# Altium schematic sheet AD Sensor Module.SchDoc("AD Sensor Module")
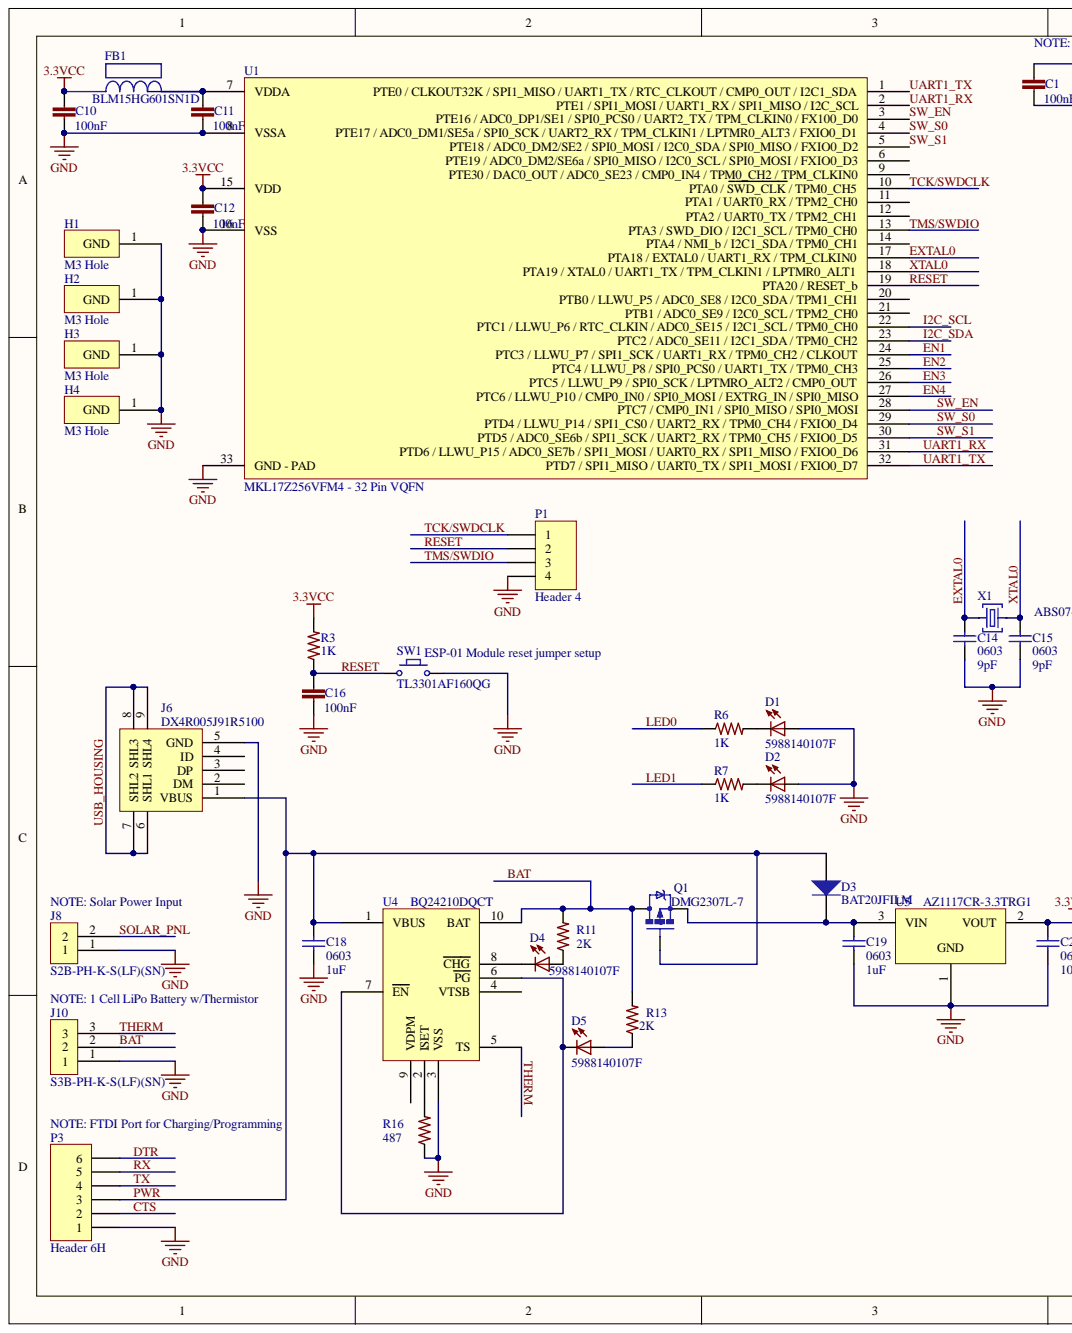
[diagram p0: AD Sensor Module.SchDoc, left]
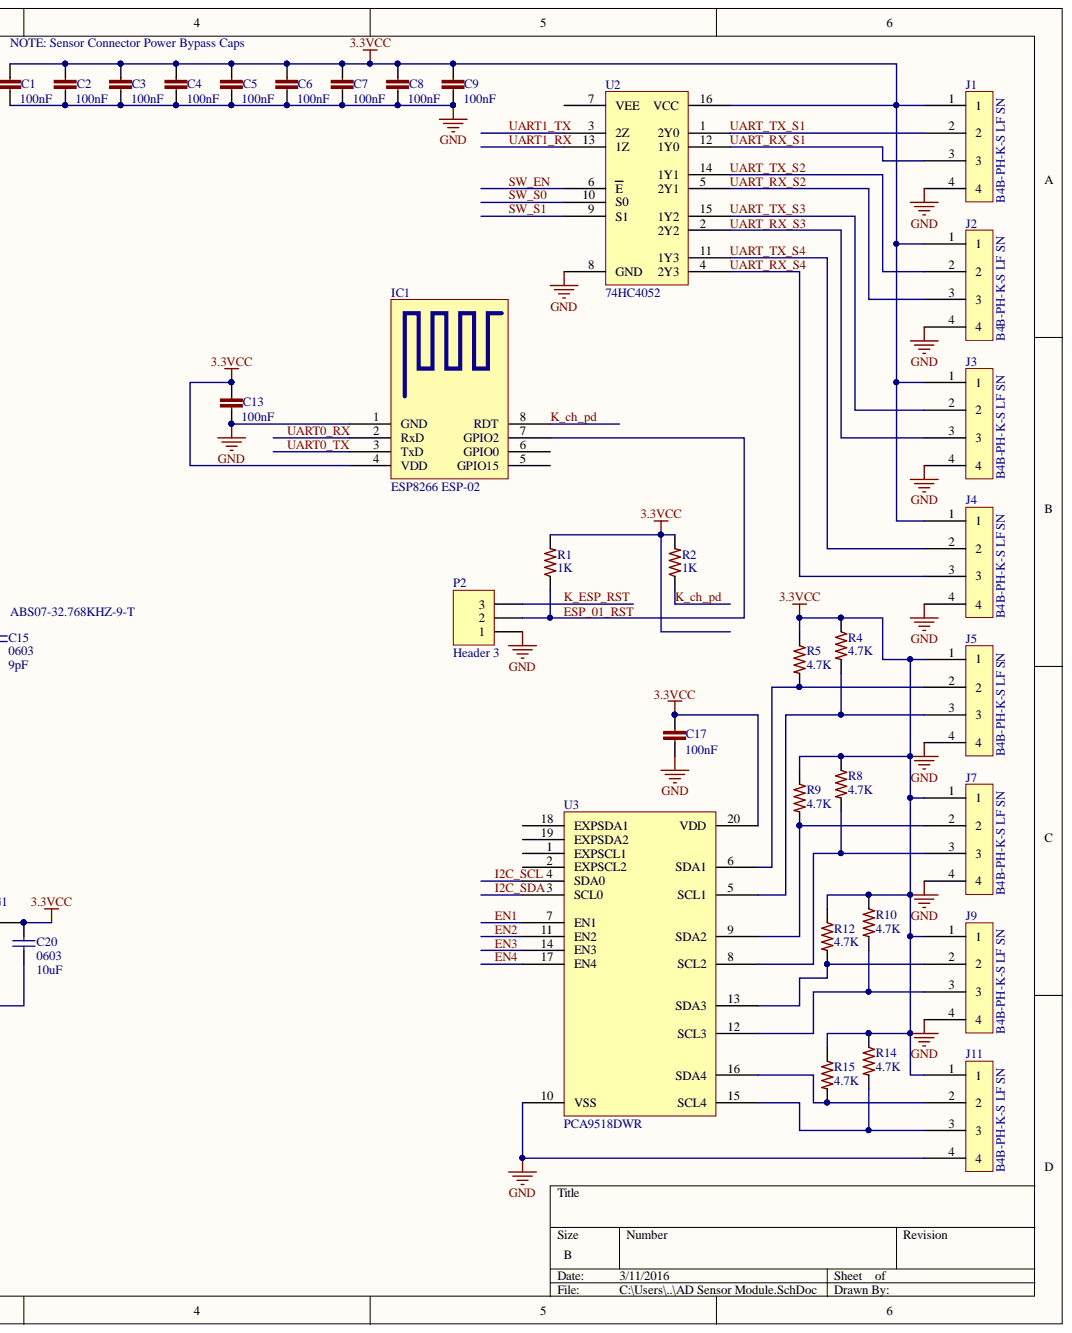
[diagram p1: AD Sensor Module.SchDoc, right]

## Parts
C1  100nF  [C0402]
C2  100nF  [C0402]
C3  100nF  [C0402]
C4  100nF  [C0402]
C5  100nF  [C0402]
C6  100nF  [C0402]
C7  100nF  [C0402]
C8  100nF  [C0402]
C9  100nF  [C0402]
C10  100nF  [C0402]
C11  100nF  [C0402]
C12  100nF  [C0402]
C13  100nF  [C0402]
C14  0603  value 9pF  value 9pF  [C0603]
C15  0603  value 9pF  value 9pF  [C0603]
C16  100nF  [C0402]
C17  100nF  [C0402]
C18  0603  value 1uF  [C0603]
C19  0603  value 1uF  [C0603]
C20  0603  value 10uF  value 10uF  [C0603]
D1  5988140107F  [LED2012120]
D2  5988140107F  [LED2012120]
D3  BAT20JFILM  [BAT20JFILM - DIOM1712X11N]
D4  5988140107F  [LED2012120]
D5  5988140107F  [LED2012120]
FB1  BLM15HG601SN1D  [BLM18PG121SN1D]
H1  M3 Hole  [Hole-3mm]
H2  M3 Hole  [Hole-3mm]
H3  M3 Hole  [Hole-3mm]
H4  M3 Hole  [Hole-3mm]
IC1  ESP8266 ESP-02  [ESP8266 ESP-02]
J1  B4B-PH-K-S LF SN  [B4B-PH-K-S(LF)(SN)]
J2  B4B-PH-K-S LF SN  [B4B-PH-K-S(LF)(SN)]
J3  B4B-PH-K-S LF SN  [B4B-PH-K-S(LF)(SN)]
J4  B4B-PH-K-S LF SN  [B4B-PH-K-S(LF)(SN)]
J5  B4B-PH-K-S LF SN  [B4B-PH-K-S(LF)(SN)]
J6  DX4R005J91R5100  [Micro USB Circular Holes Only]
J7  B4B-PH-K-S LF SN  [B4B-PH-K-S(LF)(SN)]
J8  S2B-PH-K-S(LF)(SN)  [S2B-PH-K-S(LF)(SN)]
J9  B4B-PH-K-S LF SN  [B4B-PH-K-S(LF)(SN)]
J10  S3B-PH-K-S(LF)(SN)  [S3B-PH-K-S(LF)(SN)]
J11  B4B-PH-K-S LF SN  [B4B-PH-K-S(LF)(SN)]
P1  Header 4  [HDR1X4]
P2  Header 3  [HDR1X3]
P3  Header 6H  [HDR1X6H]
Q1  DMG2307L-7  [DMG2307L-7 - SOT92P240X102-3N]
R1  1K  [R0603]
R2  1K  [R0603]
R3  1K  [R0603]
R4  4.7K  [R0603]
R5  4.7K  [R0603]
R6  1K  [R0603]
R7  1K  [R0603]
R8  4.7K  [R0603]
R9  4.7K  [R0603]
R10  4.7K  [R0603]
R11  value 2K  [R0805]  (hidden comment: ERJ-6ENF2001V)
R12  4.7K  [R0603]
R13  value 2K  [R0805]  (hidden comment: ERJ-6ENF2001V)
R14  4.7K  [R0603]
R15  4.7K  [R0603]
R16  value 487  [R0402]  (hidden comment: RC1005F4870CS)
SW1  TL3301AF160QG  [TL3301AF160QG]
U1  MKL17Z256VFM4 - 32 Pin VQFN  MFR #: MKL17Z256VFM4  [MKL17Z256VFM4 - QFN50P500X500X65_HS-33N]
U2  74HC4052  [74HC4052]
U3  PCA9518DWR  [PCA9518DWR]
U4  BQ24210DQCT  [bq24210 - QFN50P300X200X80-10N]
U5  AZ1117CR-3.3TRG1  [AZ1117CR-3.3TRG1 - SOT89-150P410X160-3N]
X1  ABS07-32.768KHZ-9-T  [ABS07-32.768KHZ-9-T]

## Nets
3.3VCC: C1.1 C2.1 C3.1 C4.1 C5.1 C6.1 C7.1 C8.1 C9.1 C10.1 C12.1 C13.1 C17.1 C20.2 FB1.1 IC1.4(VDD) J1.1 J2.1 J3.1 J4.1 J5.1 J7.1 J9.1 J11.1 R1.2 R2.2 R3.2 R4.1 R5.1 R8.1 R9.1 R10.1 R12.1 R14.1 R15.1 U1.15(VDD) U2.16(VCC) U3.20(VDD) U5.2
GND: C1.2 C2.2 C3.2 C4.2 C5.2 C6.2 C7.2 C8.2 C9.2 C10.2 C11.2 C12.2 C13.2 C14.1 C15.1 C16.2 C17.2 C18.1 C19.1 C20.1 D1.A D2.A H1.1 H2.1 H3.1 H4.1 IC1.1(GND) J1.4 J2.4 J3.4 J4.4 J5.4 J6.5(GND) J7.4 J8.1 J9.4 J10.1 J11.4 P1.4 P2.1 P3.1 R16.1 SW1.2 U1.8(VSSA) U1.16(VSS) U1.33(GND) U2.8(GND) U3.10(VSS) U4.3(VSS) U5.1
BAT: J10.2 Q1.3 R11.2 R13.2 U4.10(BAT)
CTS: P3.2
DTR: P3.6
EN1: U1.24(PTC3_/_LLWU_P7_/_SPI1_SCK_/_UART1_RX_/_TPM0_CH2_/_CLKOUT) U3.7(EN1)
EN2: U1.25(PTC4_/_LLWU_P8_/_SPI0_PCS0_/_UART1_TX_/_TPM0_CH3) U3.11(EN2)
EN3: U1.26(PTC5_/_LLWU_P9_/_SPI0_SCK_/_LPTMRO_ALT2_/_CMP0_OUT) U3.14(EN3)
EN4: U1.27(PTC6_/_LLWU_P10_/_CMP0_IN0_/_SPI0_MOSI_/_EXTRG_IN_/_SPI0_MISO) U3.17(EN4)
ESP_01_RST: IC1.7(GPIO2) P2.2 R1.1
EXTAL0: C14.2 U1.17(PTA18_/_EXTAL0_/_UART1_RX_/_TPM_CLKIN0) X1.1
I2C_SCL: U1.22(PTC1_/_LLWU_P6_/_RTC_CLKIN_/_ADC0_SE15_/_I2C1_SCL_/_TPM0_CH0) U3.4(SDA0)
I2C_SDA: U1.23(PTC2_/_ADC0_SE11_/_I2C1_SDA_/_TPM0_CH2) U3.3(SCL0)
K_CH_PD: IC1.8(RDT) R2.1
K_ESP_RST: P2.3
LED0: R6.1
LED1: R7.1
PWR: C18.2 D3.2 J6.1(VBUS) P3.3 Q1.1 U4.1(VBUS)
RESET: C16.1 P1.2 R3.1 SW1.1 U1.19(PTA20_/_RESET_b)
RX: P3.5
SOLAR_PNL: J8.2
SW_EN: U1.3(PTE16_/_ADC0_DP1/SE1_/_SPI0_PCS0_/_UART2_TX_/_TPM_CLKIN0_/_FX100_D0) U1.28(PTC7_/_CMP0_IN1_/_SPI0_MISO_/_SPI0_MOSI) U2.6(E)
SW_S0: U1.4 U1.29(PTD4_/_LLWU_P14_/_SPI1_CS0_/_UART2_RX_/_TPM0_CH4_/_FXIO0_D4) U2.10(S0)
SW_S1: U1.5(PTE18_/_ADC0_DM2/SE2_/_SPI0_MOSI_/_I2C0_SDA_/_SPI0_MISO_/_FXIO0_D2) U1.30(PTD5_/_ADC0_SE6b_/_SPI1_SCK_/_UART2_RX_/_TPM0_CH5_/_FXIO0_D5) U2.9(S1)
TCK/SWDCLK: P1.1 U1.10(PTA0_/_SWD_CLK_/_TPM0_CH5)
THERM: J10.3 U4.5(TS)
TMS/SWDIO: P1.3 U1.13(PTA3_/_SWD_DIO_/_I2C1_SCL_/_TPM0_CH0)
TX: P3.4
UART0_RX: IC1.2(RxD)
UART0_TX: IC1.3(TxD)
UART1_RX: U1.2(PTE1_/_SPI1_MOSI_/_UART1_RX_/_SPI1_MISO_/_I2C_SCL) U1.31(PTD6_/_LLWU_P15_/_ADC0_SE7b_/_SPI1_MOSI_/_UART0_RX_/_SPI1_MISO_/_FXIO0_D6) U2.13(1Z)
UART1_TX: U1.1 U1.32(PTD7_/_SPI1_MISO_/_UART0_TX_/_SPI1_MOSI_/_FXIO0_D7) U2.3(2Z)
UART_RX_S1: J1.3 U2.12(1Y0)
UART_RX_S2: J2.3 U2.5(2Y1)
UART_RX_S3: J3.3 U2.2(2Y2)
UART_RX_S4: J4.3 U2.4(2Y3)
UART_TX_S1: J1.2 U2.1(2Y0)
UART_TX_S2: J2.2 U2.14(1Y1)
UART_TX_S3: J3.2 U2.15(1Y2)
UART_TX_S4: J4.2 U2.11(1Y3)
USB_HOUSING: J6.6(SHL1) J6.7(SHL2) J6.8(SHL3) J6.9(SHL4)
XTAL0: C15.2 U1.18(PTA19_/_XTAL0_/_UART1_TX_/_TPM_CLKIN1_/_LPTMR0_ALT1) X1.2
NetC11_1: C11.1 FB1.2 U1.7(VDDA)
NetC19_2: C19.2 D3.1 Q1.2 U5.3
NetD1_C: D1.C R6.2
NetD2_C: D2.C R7.2
NetD4_A: D4.A R11.1
NetD4_C: D4.C U4.8(CHG)
NetD5_A: D5.A R13.1
NetD5_C: D5.C U4.6(PG) U4.7(EN)
NetJ5_2: J5.2 R5.2 U3.6(SDA1)
NetJ5_3: J5.3 R4.2 U3.5(SCL1)
NetJ7_2: J7.2 R9.2 U3.9(SDA2)
NetJ7_3: J7.3 R8.2 U3.8(SCL2)
NetJ9_2: J9.2 R12.2 U3.13(SDA3)
NetJ9_3: J9.3 R10.2 U3.12(SCL3)
NetJ11_2: J11.2 R15.2 U3.16(SDA4)
NetJ11_3: J11.3 R14.2 U3.15(SCL4)
NetR16_2: R16.2 U4.2(ISET)

Not connected: IC1 5(GPIO15) 6(GPIO0); J6 2(DM) 3(DP) 4(ID); U1 6(PTE19_/_ADC0_DM2/SE6a_/_SPI0_MISO_/_I2C0_SCL_/_SPI0_MOSI_/_FXIO0_D3) 9(PTE30_/_DAC0_OUT_/_ADC0_SE23_/_CMP0_IN4_/_TPM0_CH2_/_TPM_CLKIN0) 11(PTA1_/_UART0_RX_/_TPM2_CH0) 12(PTA2_/_UART0_TX_/_TPM2_CH1) 14(PTA4_/_NMI_b_/_I2C1_SDA_/_TPM0_CH1) 20(PTB0_/_LLWU_P5_/_ADC0_SE8_/_I2C0_SDA_/_TPM1_CH1) 21(PTB1_/_ADC0_SE9_/_I2C0_SCL_/_TPM2_CH0); U2 7(VEE); U3 1(EXPSCL1) 2(EXPSCL2) 18(EXPSDA1) 19(EXPSDA2); U4 4(VTSB) 9(VDPM)

## Library data of the parts
C1-C13 C16 C17 = GRM155R71C104KA88D: CAP CER 0402 100nF 16V 10% X7R; LastRevisionNote: ImportComponents; Component Kind: Standard; LastRevisionDate: 23-Apr-2014; Library Name: 3Delight.SCHLIB; ManufacturerPartNumber: GRM155R71C104KA88D; Published: 23-Apr-2014; Signal Integrity: Model Name; Simulation: Model Name; VALUE: 100nF; Publisher: UltiMachine
C14 C15 = CL10C090CB8NNNC: CAP CER 9PF 50V NP0 0603; MFR: Samsung Electro-Mechanics America, Inc.; Designer: Adam Vadala-Roth; Lead-Free ?: Yes; Pin Count #: 2; Tolerance: 10%; Type: Capacitor / Passive; Voltage: 6.3V; Component Kind: Standard; Cost: $0.00805 @ 4000; Datasheet: http://www.samsungsem.com/kr/support/product-search/mlcc/__icsFiles/afieldfile/2015/06/03/CL10C090CB8NNNC.pdf; Date Created: 11 Mar 2016; Height: 0.9mm; Last Modified: 11 Mar 2016; Library Name: Sensor Package.SchLib; MFR #: CL10C090CB8NNNC; Mounting: SMT; Status: Available
C18 C19 = CL05A105KA5NQNC: CAP CER 1UF 25V X5R 0402; MFR: Samsung; Tolerance: 1%; Voltage: 50VDC; Component Kind: Standard; Component Type: Chip Capacitor; Datasheet: http://www.samsungsem.com/kr/front/downloadcms.do?path=/kr/support/product-search/mlcc/__icsFiles/afieldfile/2014/11/05&fileName=S_CL10B223JB8NNNC.pdf; Digikey #: 1276-1445-1-ND; Height: 0.9mm; LatestRevisionDate: April 2015; Library Name: Sensor Package.SchLib; MFR Part #: CL05A105KA5NQNC; Mounting Type: SMT 0603; PackageReference: 0603; Part Description: CAP CER 1UF 25V X5R 0402; Published: 22 - Feb - 2016; Signal Integrity: Cap Semi; Simulation: CAP
C20 = CL10A106KQ8NNNC: CAP CER 10UF 6.3V X5R 0603; MFR: Samsung Electro-Mechanics America, Inc.; Designer: Adam Vadala-Roth; Lead-Free ?: Yes; Pin Count #: 2; Tolerance: 10%; Type: Capacitor / Passive; Component Kind: Standard; Cost: $0.05145; Datasheet: http://www.samsungsem.com/kr/support/product-search/mlcc/__icsFiles/afieldfile/2015/08/14/S_CL10A106KQ8NNNC.pdf; Date Created: 25 Feb 2016; Height: 0.9mm; Last Modified: 25 Feb 2016; Library Name: Sensor Package.SchLib; MFR #: CL10A106KQ8NNNC; Mounting: SMT; Status: Available; Voltage: 6.3V
D1 D2 D4 D5 = 5988140107F: LED 0805 Yellow; LastRevisionNote: ImportComponents; Component Kind: Standard; LastRevisionDate: 23-Apr-2014; Library Name: Tigershark3D.SchLib; Published: 23-Apr-2014; VALUE: 5988140107F; Publisher: UltiMachine
D3 = BAT20JFILM: DIODE SCHOTTKY 23V 1A SOD323; MFR: ST Microelectronics; Designer: Adam J Valala-Roth; Component Kind: Standard; Cost: $0.44; Digikey #: 497-3381-1-ND; LatestRevisionDate: 25 Feb 2016; Library Name: Sensor Package.SchLib; MFR#: BAT20JFILM; Mounting: SMT; Package: DO-214-AB/SMC; 2 C-Bend Leads; Body 7.9 x 5.9 mm, inc. leads (LxW); Published: 25 Feb 2016; Signal Integrity: Diode; Simulation: DIODE; Publisher: Altium Limited
H1-H4 = Hole: 3mm Hole; Component Kind: Standard; Library Name: Sensor Package.SchLib
J1-J5 J7 J9 J11 = B4B-PH-K-S LF SN: CONN HEADER PH TOP 4POS 2MM; MFR: JST; Designer: Adam Vadala-Roth; Lead-Free ?: Yes; Pin Count #: 2; Component Kind: Standard; Cost: $0.24; Datasheet: http://www.jst-mfg.com/product/pdf/eng/ePH.pdf; Date Created: 26 Feb 2016; Last Modified: 26 Feb 2016; Library Name: Sensor Package.SchLib; MFR #: B4B-PH-K-S(LF)(SN); Mounting: Throughhole; Status: Available; Type: Connector 2mm Pitch Shrouded Pin
J6 = Micro USB: Micro USB Jack B; Component Kind: Standard; Library Name: Sensor Package.SchLib
J8 = S2B-PH-K-S(LF)(SN): CONN HEADER PH SIDE 2POS 2MM; MFR: JST; Designer: Adam Vadala-Roth; Lead-Free ?: Yes; Pin Count #: 2; Component Kind: Standard; Cost: $0.17; Datasheet: http://www.jst-mfg.com/product/pdf/eng/ePH.pdf; Date Created: 26 Feb 2016; Last Modified: 26 Feb 2016; Library Name: Sensor Package.SchLib; MFR #: S2B-PH-K-S(LF)(SN); Mounting: Throughhole; Status: Available; Type: Connector 2mm Pitch Shrouded Pin
J10 = S3B-PH-K-S(LF)(SN): CONN HEADER PH SIDE 2POS 2MM; MFR: JST; Designer: Adam Vadala-Roth; Lead-Free ?: Yes; Pin Count #: 3; Component Kind: Standard; Cost: $0.17; Datasheet: http://www.jst-mfg.com/product/pdf/eng/ePH.pdf; Date Created: 11 Mar 2016; Last Modified: 11 Mar 2016; Library Name: Sensor Package.SchLib; MFR #: S3B-PH-K-S(LF)(SN); Mounting: Throughhole; Status: Available; Type: Connector 2mm Pitch Shrouded Pin
P1 = Header 4: Header, 4-Pin; Component Kind: Standard; LatestRevisionDate: 17-Jul-2002; LatestRevisionNote: Re-released for DXP Platform.; Library Name: Miscellaneous Connectors.IntLib; Signal Integrity: Connector; Publisher: Altium Limited
P2 = Header 3: Header, 3-Pin; Component Kind: Standard; LatestRevisionDate: 17-Jul-2002; LatestRevisionNote: Re-released for DXP Platform.; Library Name: Miscellaneous Connectors.IntLib; Signal Integrity: Connector; Publisher: Altium Limited
P3 = Header 6H: Header, 6-Pin, Right Angle; Component Kind: Standard; LatestRevisionDate: 17-Jul-2002; LatestRevisionNote: Re-released for DXP Platform.; Library Name: Miscellaneous Connectors.IntLib; Signal Integrity: Connector; Publisher: Altium Limited
Q1 = DMG2307L-7: MOSFET P-CH 30V 2.5A SOT-23; MFR: Diodes Incorporated; Component Kind: Standard; LatestRevisionDate: 25 Feb 2016; LatestRevisionNote: IPC-7351 Footprint Added.; Library Name: Sensor Package.SchLib; MFR#: DMG2307L-7; PackageDescription: SOT23; PackageReference: SOT23 SMT package; Published: 25 Feb 2016; Publisher: Adam Vadala-Roth
R1-R3 R6 R7 = ERJ-3EKF1001V: RES 0603 1K 100mW 1%; LastRevisionNote: ImportComponents; Component Kind: Standard; LastRevisionDate: 23-Apr-2014; ManufacturerPartNumber: ERJ-3EKF1001V; Published: 23-Apr-2014; Signal Integrity: Model Name; Simulation: Model Name; VALUE: 1K; Publisher: UltiMachine
  R1-R3: Library Name: 3Delight.SCHLIB
  R6 R7: Library Name: Tigershark3D.SchLib
R4 R5 R8-R10 R12 R14 R15 = ERJ3EKF4701V: RES 0603 4.7K 100mW 1%; LastRevisionNote: ImportComponents; Component Kind: Standard; LastRevisionDate: 23-Apr-2014; Library Name: Tigershark3D.SchLib; ManufacturerPartNumber: ERJ3EKF4701V; Published: 23-Apr-2014; Signal Integrity: Model Name; Simulation: Model Name; VALUE: 4.7K; Publisher: UltiMachine
R11 R13 = ERJ-6ENF2001V: RES SMD 2K OHM 1% 1/8W 0805; MFR: Panasonic Electronic Components; Designer: Adam Vadala-Roth; Lead-Free ?: Yes; Pin Count #: 2; Component Kind: Standard; Datasheet: http://industrial.panasonic.com/www-cgi/jvcr13pz.cgi?E+PZ+3+AOA0002+ERJ6ENF2001V+7+WW; Date Created: 10 Mar 2016; Height: 0.7mm; Last Modified: 10 Mar 2016; Library Name: Sensor Package.SchLib; MFR #: ERJ-6ENF2001V; Mounting: SMT/SMD; Status: Active; Tolerance: 1%; Type: Chip Resistor/Passive
R16 = RC1005F4870CS: RES SMD 487 OHM 1% 1/16W 0402; MFR: Samsung Electro-Mechanics America, Inc.; Designer: Adam Vadala-Roth; Lead-Free ?: Yes; Pin Count #: 2; Component Kind: Standard; Cost: 0.00203 @ 10K; Datasheet: http://industrial.panasonic.com/www-cgi/jvcr13pz.cgi?E+PZ+3+AOA0002+ERJ6ENF2001V+7+WW; Date Created: 11 Mar 2016; Height: 0.7mm; Last Modified: 11 Mar 2016; Library Name: Sensor Package.SchLib; MFR #: RC1005F4870CS; Mounting: SMT/SMD; Status: Active; Tolerance: 1%; Type: 0402 Passive
U1 = MKL17Z256VFM4 - 32 Pin VQFN: ARM Cortex M0+ Microcontroller for Low Power Applications, MKL1x Series; MFR: NXP - FreeScale; Designer: Adam Vadala-Roth; Lead-Free ?: Yes; Pin Count #: 32; Voltage: 1.7-3.3VDC; Component Kind: Standard; Date Created: 9 Mar 2016; Last Modified: 9 Mar 2016; Library Name: Sensor Package.SchLib; Mounting: SMT/SMD; Status: Active; Type: IC
U2 = 74HC4052: Dual 4-channel analog multiplexer/demultiplexer; MFR: NXP; Mouting Type: SMT; Component Kind: Standard; Datasheet: http://www.nxp.com/documents/data_sheet/74HC_HCT4052.pdf; Library Name: Sensor Package.SchLib; MFR#: 74HC4052; Type: 74 logic, analog mulitplexer/demultiplexer
U3 = PCA9518DWR: IC EXPANDABLE 5CH I2C HUB 20SOIC; MFR: Ti; Component Kind: Standard; Date Added: April 2015; Digikey#: 296-22766-1-ND; Library Name: Sensor Package.SchLib; MFR#: PCA9518DWR; Type: I2C Hub 20 Pin SOIC
U4 = BQ24210DQCT: IC BATT CHARGER LI-ION 10WSON (VBUS and Solar Voltaic Cell); MFR: Texas Instruments; Designer: Adam J Vadala-Roth; Lead-Free ?: Yes; Pin Count #: 8; Component Kind: Standard; Datasheet: http://www.ti.com/lit/ds/symlink/bq24210.pdf; Date Created: 6 Mar 2016; Height: 0.8mm; Last Modified: 6 Mar 2016; Library Name: Sensor Package.SchLib; MFR #: BQ24210DQCT; Mounting: SMT/SMD; Status: Active; Type: Solar Battery charger
U5 = AZ1117CR-3.3TRG1: IC REG LDO 3.3V 0.8A SOT89; MFR: Diodes Inc; Designer: Adam Vadala-Roth; Lead-Free ?: Yes; Pin Count #: 4; Voltage: 3V3; Component Kind: Standard; Datasheet: http://www.diodes.com/_files/datasheets/AZ1117C.pdf; Date Created: 8 Mar 2016; Last Modified: 8 Mar 2016; Library Name: Sensor Package.SchLib; MFR #: AZ1117CR-3.3TRG1; Mounting: SMT/SMD; Status: Active; Type: LDO Voltage Regulator
X1 = ABS07-32.768KHZ-9-T: 32.768kHz ±20ppm Crystal 9pF 70 kOhm -40°C ~ 85°C Surface Mount 2-SMD; MFR: Abracon LLC; Designer: Adam Vadala-Roth; Lead-Free ?: Yes; Pin Count #: 2; Component Kind: Standard; Cost: 0.18000 @ 3K; Datasheet: http://www.abracon.com/Resonators/ABS07.pdf; Date Created: 11 Mar 2016; Height: 2.5mm; Last Modified: 11 Mar 2016; Library Name: Sensor Package.SchLib; Loading Capacitance: 9pF; MFR #: ABS07-32.768KHZ-9-T; Mounting: SMT/SMD; Status: Active; Tolerance: ±20ppm; Type: Crystal Oscillator
FB1 = BLM15HG601SN1D: Component Kind: Standard; Description: BLM15HG601SN1D; Library Name: Sensor Package.SchLib
IC1 = ESP8266 ESP-02: Component Kind: Standard; Description: ESP8266 ESP-02; Library Name: ESP8266.SchLib
SW1 = TL3301AF160QG: Component Kind: Standard; Description: TL3301AF160QG; MFR#: TL3301AF160QG; Type: SMD Momentary Tactile Switch; Library Name: MongoosMini.SchLib
